# Altium schematic sheet imp005-breakout-connectors.SchDoc
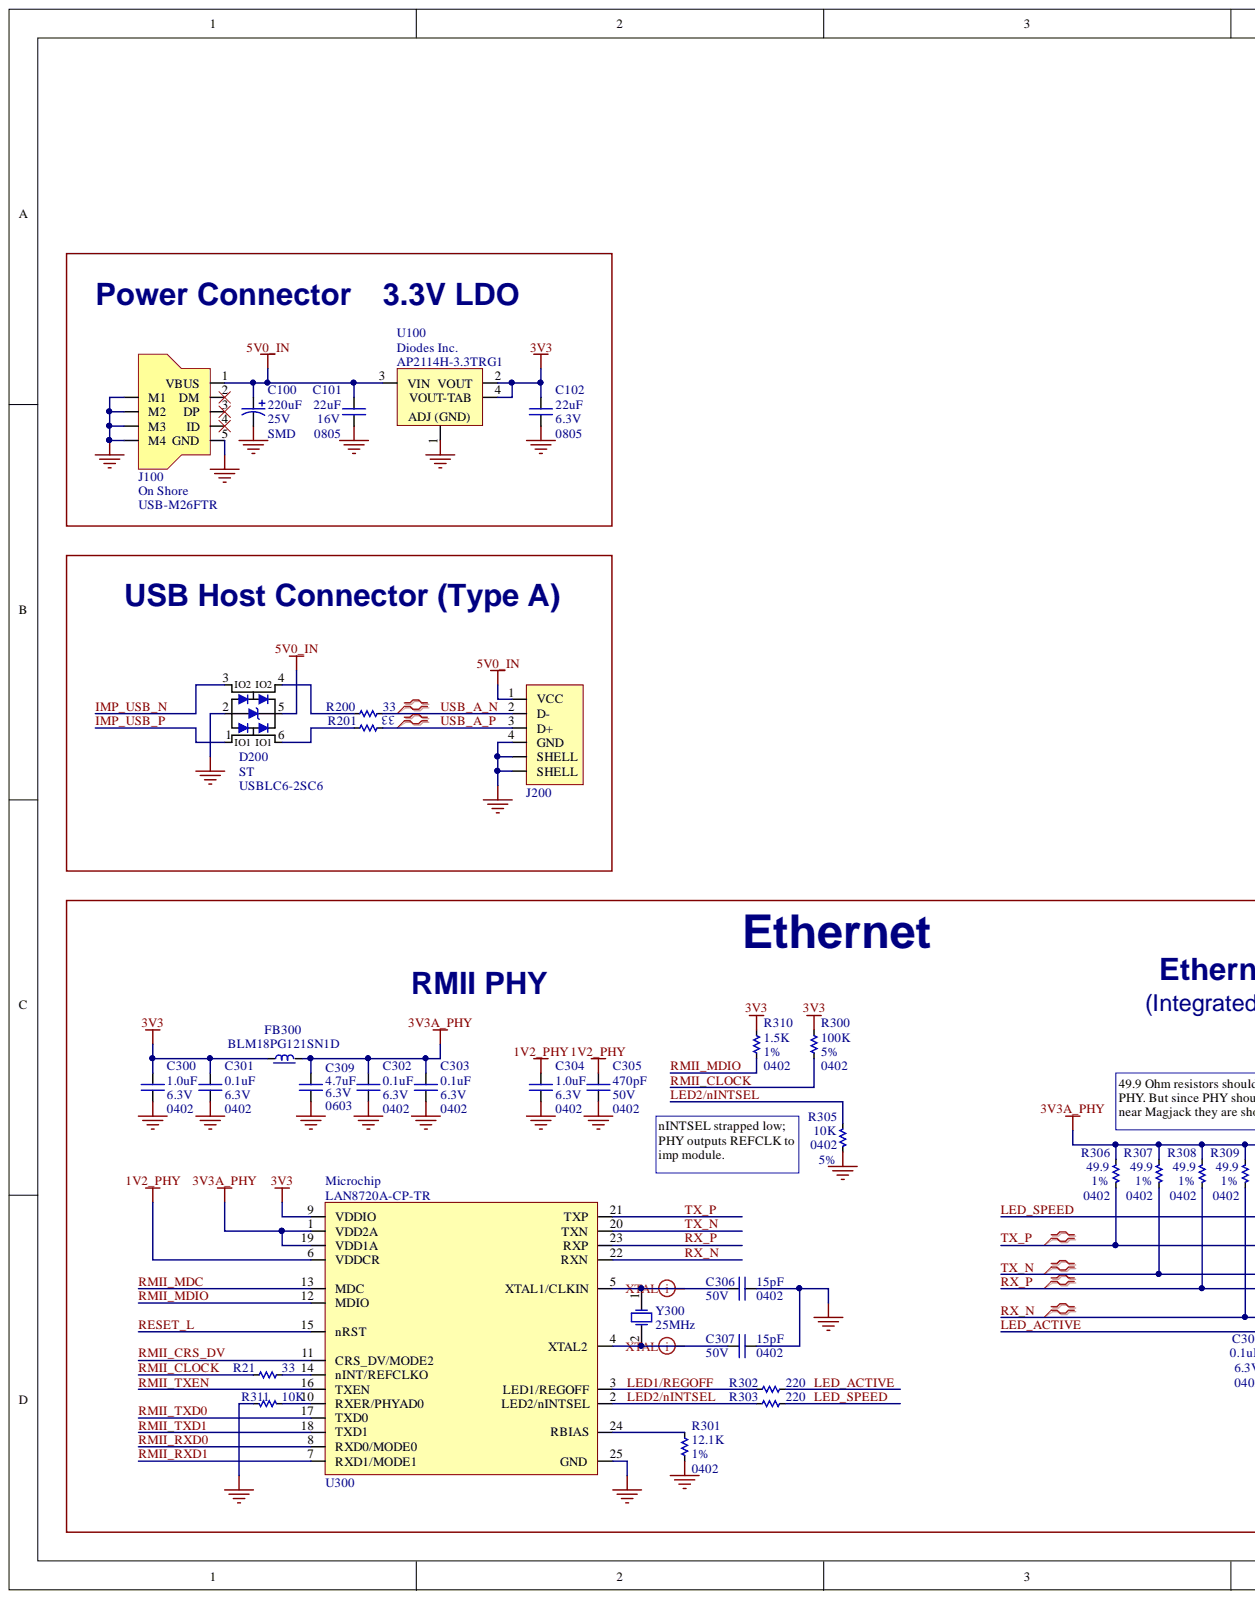
[diagram p0: imp005-breakout-connectors.SchDoc, left]
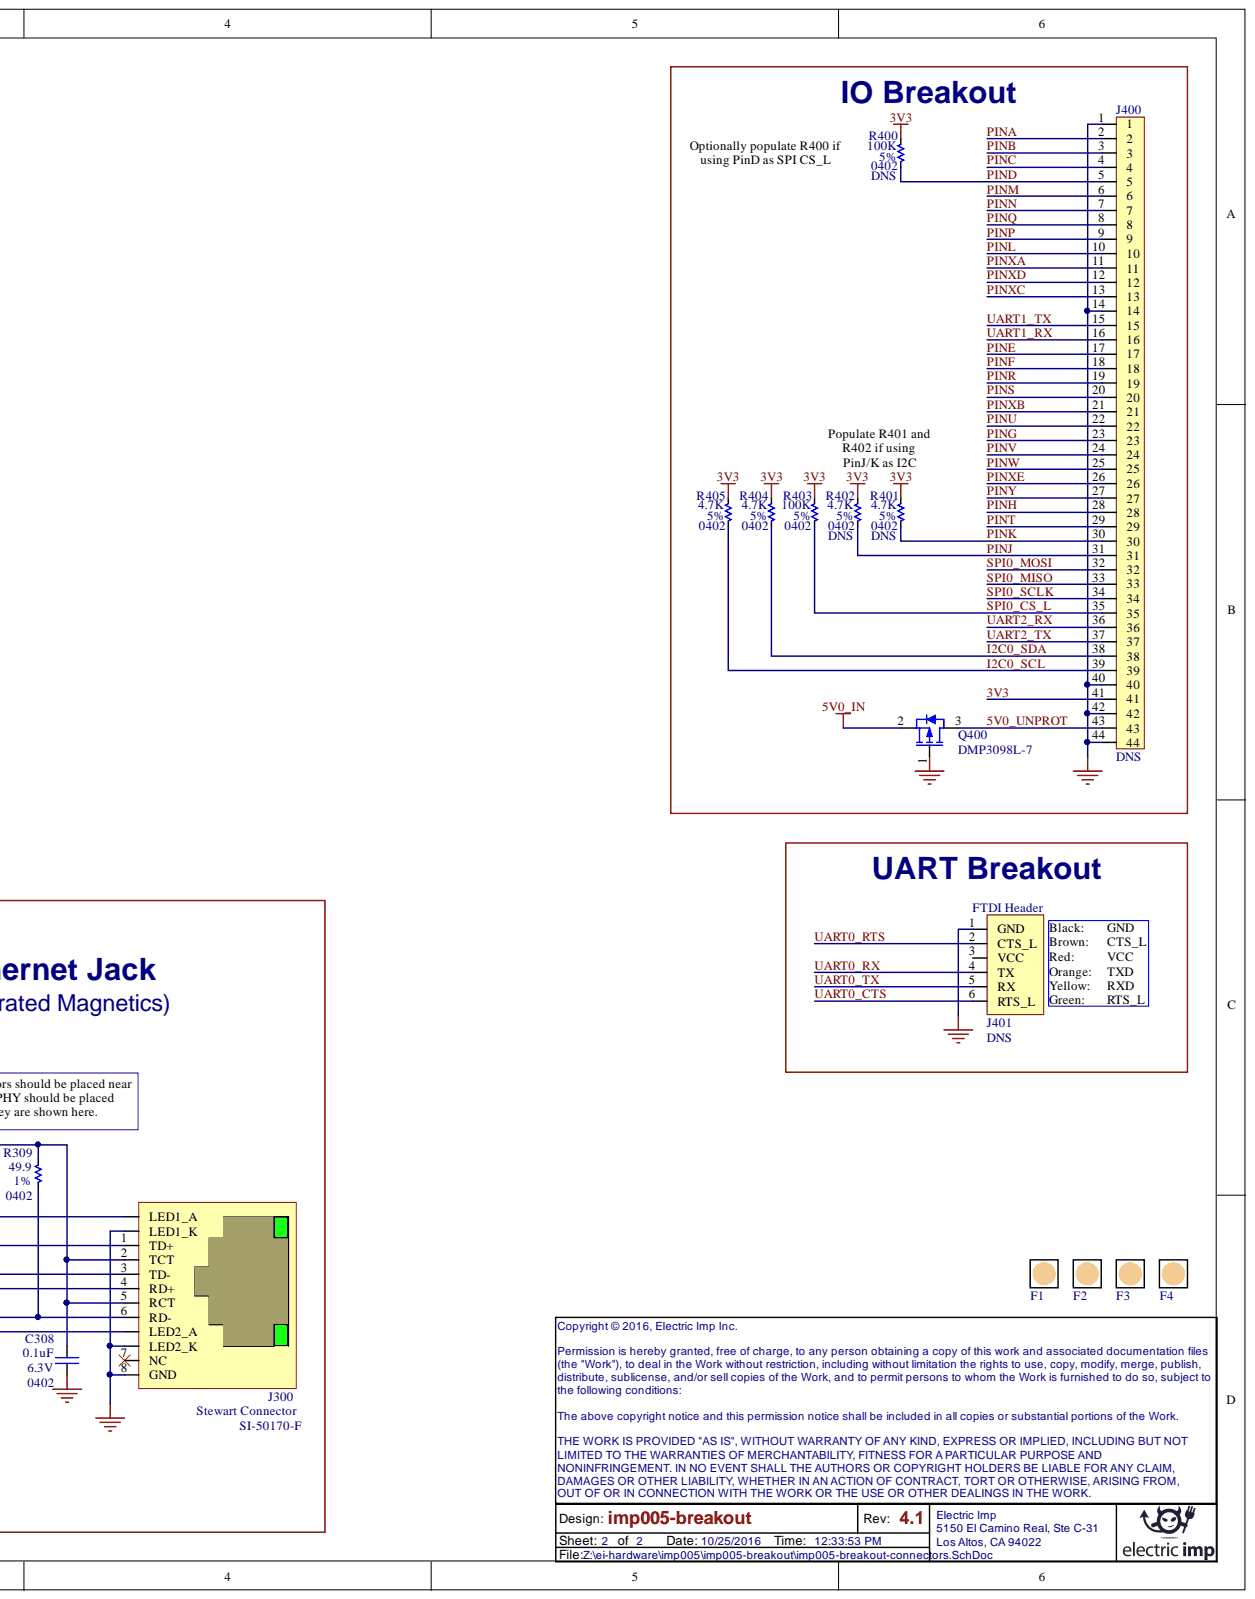
[diagram p1: imp005-breakout-connectors.SchDoc, right]

## Parts
C100  value 220uF  Package: SMD  Voltage: 25V  [EEE1EA221P]  (hidden comment: Polarized Capacitor)
C101  value 22uF  Package: 0805  Voltage: 16V  [CAPC2012X14N]  (hidden comment: Ceramic Capacitor)
C102  value 22uF  Package: 0805  Voltage: 6.3V  [CAPC2012X14N]  (hidden comment: Ceramic Capacitor)
C300  value 1.0uF  Package: 0402  Voltage: 6.3V  [CAPC1005X07N]  (hidden comment: Ceramic Capacitor)
C301  value 0.1uF  Package: 0402  Voltage: 6.3V  [CAPC1005X07N]  (hidden comment: Ceramic Capacitor)
C302  value 0.1uF  Package: 0402  Voltage: 6.3V  [CAPC1005X07N]  (hidden comment: Ceramic Capacitor)
C303  value 0.1uF  Package: 0402  Voltage: 6.3V  [CAPC1005X07N]  (hidden comment: Ceramic Capacitor)
C304  value 1.0uF  Package: 0402  Voltage: 6.3V  [CAPC1005X07N]  (hidden comment: Ceramic Capacitor)
C305  value 470pF  Package: 0402  Voltage: 50V  [CAPC1005X07N]  (hidden comment: Ceramic Capacitor)
C306  value 15pF  Package: 0402  Voltage: 50V  [CAPC1005X07N]  (hidden comment: Ceramic Capacitor)
C307  value 15pF  Package: 0402  Voltage: 50V  [CAPC1005X07N]  (hidden comment: Ceramic Capacitor)
C308  value 0.1uF  Package: 0402  Voltage: 6.3V  [CAPC1005X07N]  (hidden comment: Ceramic Capacitor)
C309  value 4.7uF  Package: 0603  Voltage: 6.3V  [CAPC1608X10N]  (hidden comment: Ceramic Capacitor)
D200  Manufacturer: ST  Manufacturer Part Number: USBLC6-2SC6  [SOT95P300X130-6N]  (hidden comment: USB ESD Array)
F1  Fiducial  [FIDUCIAL1MM]  (value not drawn)
F2  Fiducial  [FIDUCIAL1MM]  (value not drawn)
F3  Fiducial  [FIDUCIAL1MM]  (value not drawn)
F4  Fiducial  [FIDUCIAL1MM]  (value not drawn)
FB300  Manufacturer Part Number: BLM18PG121SN1D  [INDC1608X09N]  (hidden comment: Ferrite Bead)
J100  Manufacturer: On Shore  Manufacturer Part Number: USB-M26FTR  [4UCON20035]  (hidden comment: USB Mini/Micro-B)
J200  USB A Socket  [USB_A_RT]  (value not drawn)
J300  Manufacturer: Stewart Connector  [SI-50170-F]  (hidden comment: MAGJACK_SINGLE)
J400  BOMOPTION: DNS  [HDR254P1X44-N]  (hidden comment: Header)  (no BOM)
J401  FTDI Header  BOMOPTION: DNS  [HDR254P1X6-N]  (no BOM)
Q400  Manufacturer Part Number: DMP3098L-7  [SOT95P300X130-3N]  (hidden comment: PFET)
R21  value 33  [RESC1005X04N]  (hidden comment: Resistor)
R200  value 33  [RESC1005X04N]  (hidden comment: Resistor)
R201  value 33  [RESC1005X04N]  (hidden comment: Resistor)
R300  value 100K  Package: 0402  Tolerance: 5%  [RESC1005X04N]  (hidden comment: Resistor)
R301  value 12.1K  Package: 0402  Tolerance: 1%  [RESC1005X04N]  (hidden comment: Resistor)
R302  value 220  [RESC1005X04N]  (hidden comment: Resistor)
R303  value 220  [RESC1005X04N]  (hidden comment: Resistor)
R305  value 10K  Package: 0402  Tolerance: 5%  [RESC1005X04N]  (hidden comment: Resistor)
R306  value 49.9  Package: 0402  Tolerance: 1%  [RESC1005X04N]  (hidden comment: Resistor)
R307  value 49.9  Package: 0402  Tolerance: 1%  [RESC1005X04N]  (hidden comment: Resistor)
R308  value 49.9  Package: 0402  Tolerance: 1%  [RESC1005X04N]  (hidden comment: Resistor)
R309  value 49.9  Package: 0402  Tolerance: 1%  [RESC1005X04N]  (hidden comment: Resistor)
R310  value 1.5K  Package: 0402  Tolerance: 1%  [RESC1005X04N]  (hidden comment: Resistor)
R311  value 10K  [RESC1005X04N]  (hidden comment: Resistor)
R400  value 100K  BOMOPTION: DNS  Package: 0402  Tolerance: 5%  [RESC1005X04N]  (hidden comment: Resistor)  (no BOM)
R401  value 4.7K  BOMOPTION: DNS  Package: 0402  Tolerance: 5%  [RESC1005X04N]  (hidden comment: Resistor)  (no BOM)
R402  value 4.7K  BOMOPTION: DNS  Package: 0402  Tolerance: 5%  [RESC1005X04N]  (hidden comment: Resistor)  (no BOM)
R403  value 100K  Package: 0402  Tolerance: 5%  [RESC1005X04N]  (hidden comment: Resistor)
R404  value 4.7K  Package: 0402  Tolerance: 5%  [RESC1005X04N]  (hidden comment: Resistor)
R405  value 4.7K  Package: 0402  Tolerance: 5%  [RESC1005X04N]  (hidden comment: Resistor)
U100  Manufacturer: Diodes Inc.  Manufacturer Part Number: AP2114H-3.3TRG1  [SOT230P700X180-4N]  (hidden comment: AP1117)
U300  LAN8720  Manufacturer: Microchip  Manufacturer Part Number: LAN8720A-CP-TR  [QFN50P400X400X100_HS-25N]
Y300  XTAL  Frequency: 25MHz  [HC-49S]

## Nets
1V2_PHY: C304.2 C305.2 U300.6(VDDCR)
3V3A_PHY: C302.2 C303.2 C308.2 C309.2 FB300.2 J300.2(TCT) J300.5(RCT) R306.1 R307.1 R308.1 R309.1 U300.1(VDD2A) U300.19(VDD1A)
5V0_IN: C100.1 C101.2 D200.5 J100.1(VBUS) J200.1(VCC) Q400.2 U100.3(VIN)
GND: C100.2 C101.1 C102.1 C300.1 C301.1 C302.1 C303.1 C304.1 C305.1 C306.1 C307.1 C308.1 C309.1 D200.2 J100.5(GND) J100.M1 J100.M2 J100.M3 J100.M4 J200.4(GND) J200.5(SHELL) J200.6(SHELL) J300.8(GND) J300.LED1_K J300.LED2_K J400.1 J400.14 J400.40 J400.42 J400.44 J401.1(GND) Q400.1 R301.2 R305.2 R311.1 U100.1 U300.25(GND)
3V3: C102.2 C300.2 C301.2 FB300.1 J400.41 R300.1 R310.1 R400.1 R401.1 R402.1 R403.1 R404.1 R405.1 U100.2(VOUT) U100.4(VOUT-TAB) U300.9(VDDIO)
5V0_UNPROT: J400.43 Q400.3
I2C0_SCL: J400.39 R405.2
I2C0_SDA: J400.38 R404.2
IMP_USB_N: D200.3(IO2)
IMP_USB_P: D200.1(IO1)
LED1/REGOFF: R302.1 U300.3(LED1/REGOFF)
LED2/NINTSEL: R303.1 R305.1 U300.2(LED2/nINTSEL)
LED_ACTIVE: J300.LED2_A R302.2
LED_SPEED: J300.LED1_A R303.2
PINA: J400.2
PINB: J400.3
PINC: J400.4
PIND: J400.5 R400.2
PINE: J400.17
PINF: J400.18
PING: J400.23
PINH: J400.28
PINJ: J400.31 R402.2
PINK: J400.30 R401.2
PINL: J400.10
PINM: J400.6
PINN: J400.7
PINP: J400.9
PINQ: J400.8
PINR: J400.19
PINS: J400.20
PINT: J400.29
PINU: J400.22
PINV: J400.24
PINW: J400.25
PINXA: J400.11
PINXB: J400.21
PINXC: J400.13
PINXD: J400.12
PINXE: J400.26
PINY: J400.27
RESET_L: U300.15(nRST)
RMII_CLOCK: R21.1 R300.2
RMII_CRS_DV: U300.11(CRS_DV/MODE2)
RMII_MDC: U300.13(MDC)
RMII_MDIO: R310.2 U300.12(MDIO)
RMII_RXD0: U300.8(RXD0/MODE0)
RMII_RXD1: U300.7(RXD1/MODE1)
RMII_TXD0: U300.17(TXD0)
RMII_TXD1: U300.18(TXD1)
RMII_TXEN: U300.16(TXEN)
RX_N: J300.6(RD-) R309.2 U300.22(RXN)
RX_P: J300.4(RD+) R308.2 U300.23(RXP)
SPI0_CS_L: J400.35 R403.2
SPI0_MISO: J400.33
SPI0_MOSI: J400.32
SPI0_SCLK: J400.34
TX_N: J300.3(TD-) R307.2 U300.20(TXN)
TX_P: J300.1(TD+) R306.2 U300.21(TXP)
UART0_CTS: J401.6(RTS_L)
UART0_RTS: J401.2(CTS_L)
UART0_RX: J401.4(TX)
UART0_TX: J401.5(RX)
UART1_RX: J400.16
UART1_TX: J400.15
UART2_RX: J400.36
UART2_TX: J400.37
USB_A_N: J200.2(D-) R200.2
USB_A_P: J200.3(D+) R201.2
NetC306_2: C306.2 U300.5(XTAL1/CLKIN) Y300.1
NetC307_2: C307.2 U300.4(XTAL2) Y300.2
NetD200_4: D200.4(IO2) R200.1
NetD200_6: D200.6(IO1) R201.1
NetR21_2: R21.2 U300.14(nINT/REFCLKO)
NetR301_1: R301.1 U300.24(RBIAS)
NetR311_2: R311.2 U300.10(RXER/PHYAD0)

Not connected: J100 2(DM) 3(DP) 4(ID); J300 7(NC); J401 3(VCC)

## Library data of the parts
C100 = CAPC00071: CAP,ALUM,220uF,20%,25V,10mm DIA,SMD; Manufacturer: Panasonic; Manufacturer Part Number: EEE-1EA221P; Database Table Name: capacitor$; Component Kind: Standard; Dielectric: Alum; Library Name: passives.DbLib; Part Number: CAPC00071; Supplier 1: Digi-Key; Supplier Part Number 1: PCE3901TR-ND; Supplier Price 1: 0.1863; Tolerance: 20 %
C101 = CAPC00051: CAP,X5R,22uF,20%,16V,0805; Manufacturer: TDK; Manufacturer Part Number: C2012X5R1C226M; Database Table Name: capacitor$; Component Kind: Standard; Dielectric: X5R; Library Name: passives.DbLib; Part Number: CAPC00051; Supplier 1: Digi-Key; Supplier Part Number 1: 445-7647-1-ND; Supplier Price 1: 0.225; Tolerance: 20%
C102 = CAPC00004: CAP,X5R,22uF,20%,6.3V,0805,#; Manufacturer: Samsung; Manufacturer Part Number: CL21A226MQCLQNC; Database Table Name: capacitor$; Component Kind: Standard; Dielectric: X5R; Library Name: passives.DbLib; Manufacturer 2: AVX; Manufacturer Part Number 2: 08056D226MAT2A; Part Number: CAPC00004; Supplier 1: Digi-Key; Supplier 2: Digi-Key; Supplier Part Number 1: 1276-2412-1-ND; Supplier Part Number 2: 478-3647-1-ND; Supplier Price 1: 0.0561; Supplier Price 2: 0.0561; Tolerance: 20%
C300 C304 = CAPC00005: CAP,X5R,1.0uF,10%,6.3V, 0402,#; Manufacturer: Murata; Manufacturer Part Number: GRM155R60J105KE19D; Database Table Name: capacitor$; Component Kind: Standard; Dielectric: X5R; Library Name: passives.DbLib; Manufacturer 2: Samsung; Manufacturer Part Number 2: CL05A105KQ5NNNC; Part Number: CAPC00005; Stock Date: 3/12/2013; Stock Quantity: 1000; Supplier 1: Digi-Key; Supplier 2: Digi-Key; Supplier Part Number 1: 490-1320-1-ND; Supplier Part Number 2: 1276-1010-1-ND; Supplier Price 1: 0.0087; Supplier Price 2: 0.009; Tolerance: 10%
C301-C303 C308 = CAPC00002: CAP,X5R,0.1uF,10%,6.3V, 0402,#; Manufacturer: TDK; Manufacturer Part Number: C1005X5R0J104K; Database Table Name: capacitor$; Component Kind: Standard; Dielectric: X5R; Library Name: passives.DbLib; Manufacturer 2: Murata; Manufacturer Part Number 2: GRM155R60J104KA01D; Part Number: CAPC00002; Stock Date: 4/19/2013; Stock Quantity: 300; Supplier 1: Digi-Key; Supplier 2: Mouser; Supplier Part Number 1: 445-1266-1-ND; Supplier Part Number 2: 81-GRM155R60J104KA1D; Supplier Price 1: 0.0066; Supplier Price 2: 0.007; Tolerance: 10%
C305 = CAPC00047: CAP,C0G,470pF,5%,50V,0402; Manufacturer: TDK; Manufacturer Part Number: C1005C0G1H471J; Database Table Name: capacitor$; Component Kind: Standard; Dielectric: C0G; Library Name: passives.DbLib; Manufacturer 2: Murata; Manufacturer Part Number 2: GRM1555C1H471JA01D; Part Number: CAPC00047; Stock Date: 5/6/2013; Stock Quantity: 50; Supplier 1: Digi-Key; Supplier 2: Digi-Key; Supplier Part Number 1: 445-2656-1-ND; Supplier Part Number 2: 490-1297-1-ND; Supplier Price 1: 0.0108; Supplier Price 2: 0.0177; Tolerance: 5%
C306 C307 = CAPC00105: CAP,C0G,15pF,5%,50V,0402; Manufacturer: Samsung; Manufacturer Part Number: CL05C150JB5NNNC; Database Table Name: capacitor$; Component Kind: Standard; Dielectric: C0G; Library Name: passives.DbLib; Part Number: CAPC00105; Supplier 1: Digi-Key; Supplier Part Number 1: 1276-1179-1-ND; Supplier Price 1: 0.00324; Tolerance: 5%
C309 = CAPC00033: CAP,X5R,4.7uF,10%,6.3V,0603,#; Manufacturer: Taiyo Yuden; Manufacturer Part Number: JMK107BJ475KA-T; Database Table Name: capacitor$; Component Kind: Standard; Dielectric: X5R; Library Name: passives.DbLib; Manufacturer 2: Yageo; Manufacturer Part Number 2: CC0603KRX5R5BB475; Part Number: CAPC00033; Supplier 1: Digi-Key; Supplier 2: Digi-Key; Supplier Part Number 1: 587-1785-1-ND; Supplier Part Number 2: 311-1521-1-ND; Supplier Price 1: 0.02475; Supplier Price 2: 0.02899; Tolerance: 10%
D200 = TVS00007: TVS Array, USB Flowthough, Powerline Clamp, SOT23-6; Database Table Name: TVS$; Max VDC: 5.25V; Varistor Voltage: 6V; Component Kind: Standard; ComponentLink1URL: http://www.digikey.com/product-detail/en/USBLC6-2SC6/497-5235-1-ND/1121688; Imax: -; Library Name: discretes.DbLib; Part Number: TVS00007; Supplier 1: Digi-Key; Supplier Part Number 1: 497-5235-1-ND; Supplier Price 1: 0.15725
F1-F4 = ELEC00015: Database Table Name: misc$; Component Kind: Net Tie; Description: Fiducial; Library Name: electrical.DbLib; Part Number: ELEC00015
FB300 = FB00006: FERR BD, 120 Ohm @ 100Mhz, 2A, 50 mOhm, 0603; Manufacturer: Murata; Database Table Name: ferrite$; DC Resistance (DCR): 50 mOhm; Component Kind: Standard; ComponentLink1URL: http://www.digikey.com/product-detail/en/BLM18PG121SN1D/490-1037-1-ND/584485; Current Rating: 2A; Impedance @ Frequency: 120 Ohm @ 100Mhz; Library Name: passives.DbLib; Package: 0603; Part Number: FB00006; Stock Quantity: 0; Supplier 1: Digi-Key; Supplier Part Number 1: 490-1037-1-ND; Supplier Price 1: 0.02884
J100 = USB00001: USB Mini-B Connector; Database Table Name: USB$; Component Kind: Standard; ComponentLink1URL: http://www.digikey.com/product-detail/en/USB-M26FTR/ED2992TR-ND/2677753; Library Name: connectors.DbLib; Manufacturer 2: 4UCON; Manufacturer Part Number 2: 20035; Part Number: USB00001; Stock Date: 4/19/2013; Stock Qty: 50; Supplier 1: Digi-Key; Supplier 2: 4UCON; Supplier Part Number 1: ED2992TR-ND; Supplier Part Number 2: 20035; Supplier Price 1: 0.4368; Supplier Price 2: 0.0925
J200 = USB00002: Conn, USB, RCPT, Type A, RT Angle, TH; Manufacturer: Assmann; Manufacturer Part Number: AU-Y1005-R; Database Table Name: USB$; Component Kind: Standard; ComponentLink1URL: http://www.digikey.com/product-detail/en/AU-Y1005-R/AE9923-ND/821677; Library Name: connectors.DbLib; Manufacturer 2: EDAC; Manufacturer Part Number 2: 690-004-621-013; Part Number: USB00002; Supplier 1: Digi-Key; Supplier 2: Digi-Key; Supplier Part Number 1: AE9923-ND; Supplier Part Number 2: 151-1080-ND; Supplier Price 1: 0.3267; Supplier Price 2: 0.6625
J300 = CON00042: Connector, RJ45 with Magnetics, Single Port, 100 BASE-T, R/A; Database Table Name: misc$; Component Kind: Standard; ComponentLink1URL: http://www.digikey.com/product-search/en?vendor=0&keywords=SI-50170-F; Library Name: connectors.DbLib; Manufacturer Part Number: SI-50170-F; Part Number: CON00042; Supplier 1: Digi-Key; Supplier Part Number 1: 380-1103-ND; Supplier Price 1: 2.7434
J400 = HDR00055: HDR, 1x44 Pin, 2.54mm Pitch, Vertical, TH; Manufacturer: Sullins; Manufacturer Part Number: TSW-144-07-T-S; Connector Type: Unshrouded; Database Table Name: headers$; Gender: Male; Number of Columns: 44; Contact Finish: Tin; Library Name: connectors.DbLib; Mounting Type: Through Hole; Number of Positions Loaded: 44; Number of Rows: 1; Orientation: Vertical; Part Number: HDR00055; Pitch: 2.54mm; Supplier 1: Digi-Key; Supplier Part Number 1: SAM1035-44-ND; Supplier Price 1: 1.05714
J401 = ELEC00023: FTDI Cable Header; Database Table Name: misc$; Library Name: electrical.DbLib; Part Number: ELEC00023
Q400 = PFET00004: PFET, 30V, 3.8A, 20Vgs, SOT23; Manufacturer: Diodes Inc; Database Table Name: PFET$; Power - Max: 1.08W; Rds On (Max) @ Id, Vgs: 56 mOhm @ 3.8A, 10V; Vgs(th) (Max) @ Id: 1.8V @ 250uA; Component Kind: Standard; ComponentLink1URL: http://www.digikey.com/product-detail/en/DMP3098L-7/DMP3098LDICT-ND/1964773; Current - Continuous Drain (Id) @ 25° C: 3.8A; Drain to Source Voltage (Vdss): 30V; Library Name: discretes.DbLib; Part Number: PFET00004; Simulation: PMOS; Stock Date: 3/5/2013; Stock Quantity: 213; Supplier 1: Digi-key; Supplier Part Number 1: DMP3098LDITR-ND; Supplier Price 1: 0.111
R21 R200 R201 = RES00057: RES,33 Ohm,1%,0.063W,0402; Manufacturer: Rohm; Manufacturer Part Number: MCR01MRTF33R0; Database Table Name: resistor$; Component Kind: Standard; Library Name: passives.DbLib; Manufacturer 2: Yageo; Manufacturer Part Number 2: RC0402FR-0733RL; Package: 0402; Part Number: RES00057; Power: 0.063W; Supplier 1: Digi-Key; Supplier 2: Digi-Key; Supplier Part Number 1: RHM33.0CDTR-ND; Supplier Part Number 2: 311-33.0LRTR-ND; Supplier Price 1: 0.00132; Supplier Price 2: 0.00149; Tolerance: 1%
R300 R400 R403 = RES00001: RES,100K,5%,0.063W,0402,#; Manufacturer: Samsung; Manufacturer Part Number: RC1005J104CS; Database Table Name: resistor$; Library Name: passives.DbLib; Manufacturer 2: ROHM; Manufacturer Part Number 2: MCR01MRTJ104; Part Number: RES00001; Power: 0.063W; Stock Date: 4/5/2013; Stock Quantity: 2100; Supplier 1: Digi-Key; Supplier 2: Digi-Key; Supplier Part Number 1: 1276-4424-1-ND; Supplier Part Number 2: HM100KCECT-ND; Supplier Price 1: 0.0023; Supplier Price 2: 0.00233
  R300 R403: Component Kind: Standard
R301 = RES00103: RES,12.1K Ohm,1%,0.063W,0402; Manufacturer: Samsung; Manufacturer Part Number: RC1005F1212CS; Database Table Name: resistor$; Component Kind: Standard; Library Name: passives.DbLib; Manufacturer 2: Rohm; Manufacturer Part Number 2: MCR01MRTF1212; Part Number: RES00103; Power: 0.063W; Supplier 1: Digi-Key; Supplier 2: Digi-Key; Supplier Part Number 1: 1276-3435-1-ND; Supplier Part Number 2: RHM12.1KCDCT-ND; Supplier Price 1: 0.00233; Supplier Price 2: 0.00247
R302 R303 = RES00011: RES,220 Ohm,5%,0.063W,0402; Manufacturer: Yageo; Manufacturer Part Number: RC0402JR-07220RL; Database Table Name: resistor$; Component Kind: Standard; Library Name: passives.DbLib; Manufacturer 2: Panasonic; Manufacturer Part Number 2: ERJ-2GEJ221X; Package: 0402; Part Number: RES00011; Power: 0.063W; Supplier 1: Digi-Key; Supplier 2: Digi-Key; Supplier Part Number 1: 311-220JRCT-ND; Supplier Part Number 2: P220JCT-ND; Supplier Price 1: 0.00237; Supplier Price 2: 0.0048; Tolerance: 5%
R305 R311 = RES00006: RES,10K,5%,0.063W,0402,#; Manufacturer: Stackpole; Manufacturer Part Number: RMCF0402JT10K0; Database Table Name: resistor$; Component Kind: Standard; Library Name: passives.DbLib; Manufacturer 2: ROHM; Manufacturer Part Number 2: MCR01MRTJ103; Part Number: RES00006; Power: 0.063W; Stock Date: 4/19/2013; Stock Quantity: 120; Supplier 1: Digi-Key; Supplier 2: Digi-Key; Supplier Part Number 1: RMCF0402JT10K0CT-ND; Supplier Part Number 2: RHM10KCECT-ND; Supplier Price 1: 0.00256; Supplier Price 2: 0.00352
  R311: Package: 0402; Tolerance: 5%
R306-R309 = RES00104: RES,49.9 Ohm,1%,0.063W,0402; Manufacturer: Rohm; Manufacturer Part Number: MCR03ERTF49R9; Database Table Name: resistor$; Power: 0.1W; Component Kind: Standard; Library Name: passives.DbLib; Manufacturer 2: Samsung; Manufacturer Part Number 2: RC1608F49R9CS; Part Number: RES00104; Supplier 1: Digi-Key; Supplier 2: Digi-Key; Supplier Part Number 1: RHM49.9CFCT-ND; Supplier Part Number 2: 1276-3505-1-ND; Supplier Price 1: 0.0021; Supplier Price 2: 0.0021
R310 = RES00100: RES,1.5K Ohm,1%,0.063W,0402; Manufacturer: Rohm; Manufacturer Part Number: MCR01MRTF1501; Database Table Name: resistor$; Component Kind: Standard; Library Name: passives.DbLib; Part Number: RES00100; Power: 0.063W; Supplier 1: Digi-Key; Supplier Part Number 1: RHM1.50KCDCT-ND; Supplier Price 1: 0.00233
R401 R402 R404 R405 = RES00005: RES,4.7K,5%,0.063W,0402,#; Manufacturer: Yageo; Manufacturer Part Number: RC0402JR-074K7L; Database Table Name: resistor$; Library Name: passives.DbLib; Manufacturer 2: ROHM; Manufacturer Part Number 2: MCR01MRTJ472; Part Number: RES00005; Power: 0.063W; Stock Date: 4/19/2013; Stock Quantity: 100; Supplier 1: Digi-Key; Supplier 2: Digi-Key; Supplier Part Number 1: 311-4.7KJRCT-ND; Supplier Part Number 2: RHM4.7KCECT-ND; Supplier Price 1: 0.00237; Supplier Price 2: 0.00352
  R404 R405: Component Kind: Standard
U100 = IC00159: IC, LDO, Fixed 3.3V, 1A, 6VIN Max, Ceramic Stable, SOT-223; Database Table Name: misc$; Component Kind: Standard; ComponentLink1URL: http://www.digikey.com/product-detail/en/AP2114H-3.3TRG1/AP2114H-3.3TRG1DICT-ND/4505142; Library Name: ic.DbLib; Part Number: IC00159; Supplier 1: Digi-Key; Supplier Part Number 1: AP2114H-3.3TRG1DICT-ND; Supplier Price 1: 0.1305
U300 = IC00178: IC, TXRX PHY, RMII, 10/100, 24WVQFN; Database Table Name: misc$; Component Kind: Standard; ComponentLink1URL: http://www.digikey.com/product-detail/en/LAN8720A-CP-TR/LAN8720A-CP-CT-ND/4307900; Library Name: ic.DbLib; Part Number: IC00178; Supplier 1: Digi-Key; Supplier Part Number 1: LAN8720A-CP-CT-ND; Supplier Price 1: 0.76
Y300 = XTAL00022: XTAL, 25MHz, 50ppm, 20pF, 30 Ohm, HC49S; Manufacturer: TXC; Manufacturer Part Number: 9C-25.000MBBK-T; Database Table Name: xtal$; Operating Mode: Fundamental; Component Kind: Standard; ComponentLink1URL: http://www.digikey.com/product-detail/en/9C-25.000MBBK-T/887-2444-1-ND/4475438; Frequency Stability: 50ppm; Frequency Tolerance: 50ppm; Load Capacitance: 20pF; Package: HC-49S; Part Number: XTAL00022; Supplier 1: Digi-Key; Supplier Part Number 1: 887-2444-1-ND; Supplier Price 1: 0.24; Library Name: xtalosc.DbLib
